# Altium schematic sheet Sheet1.SchDoc
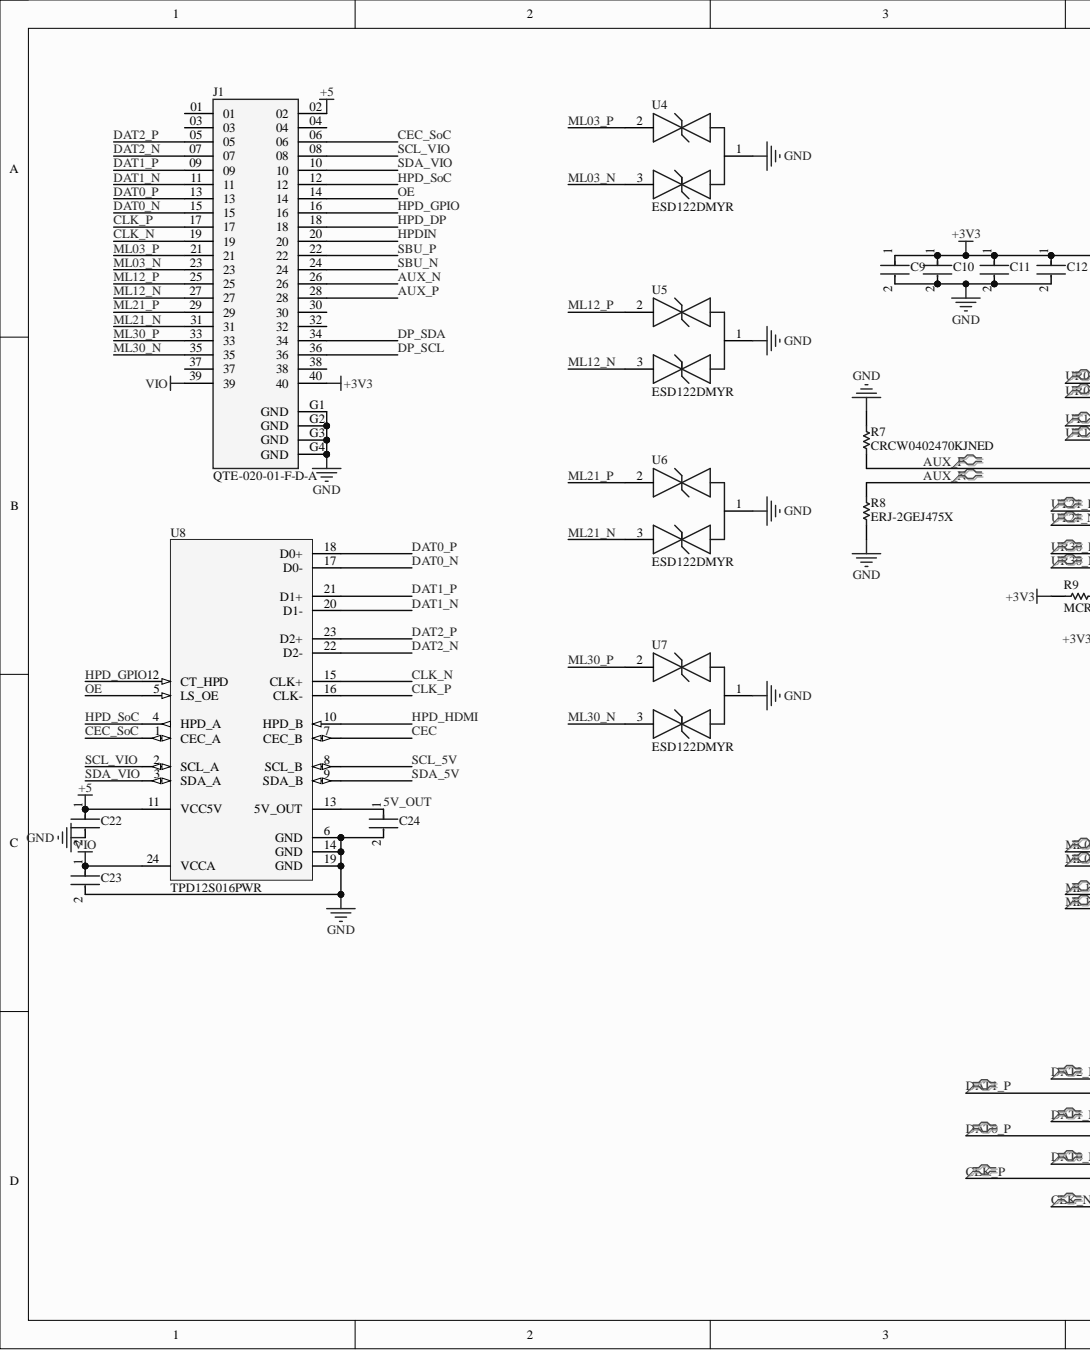
[diagram p0: Sheet1.SchDoc, left]
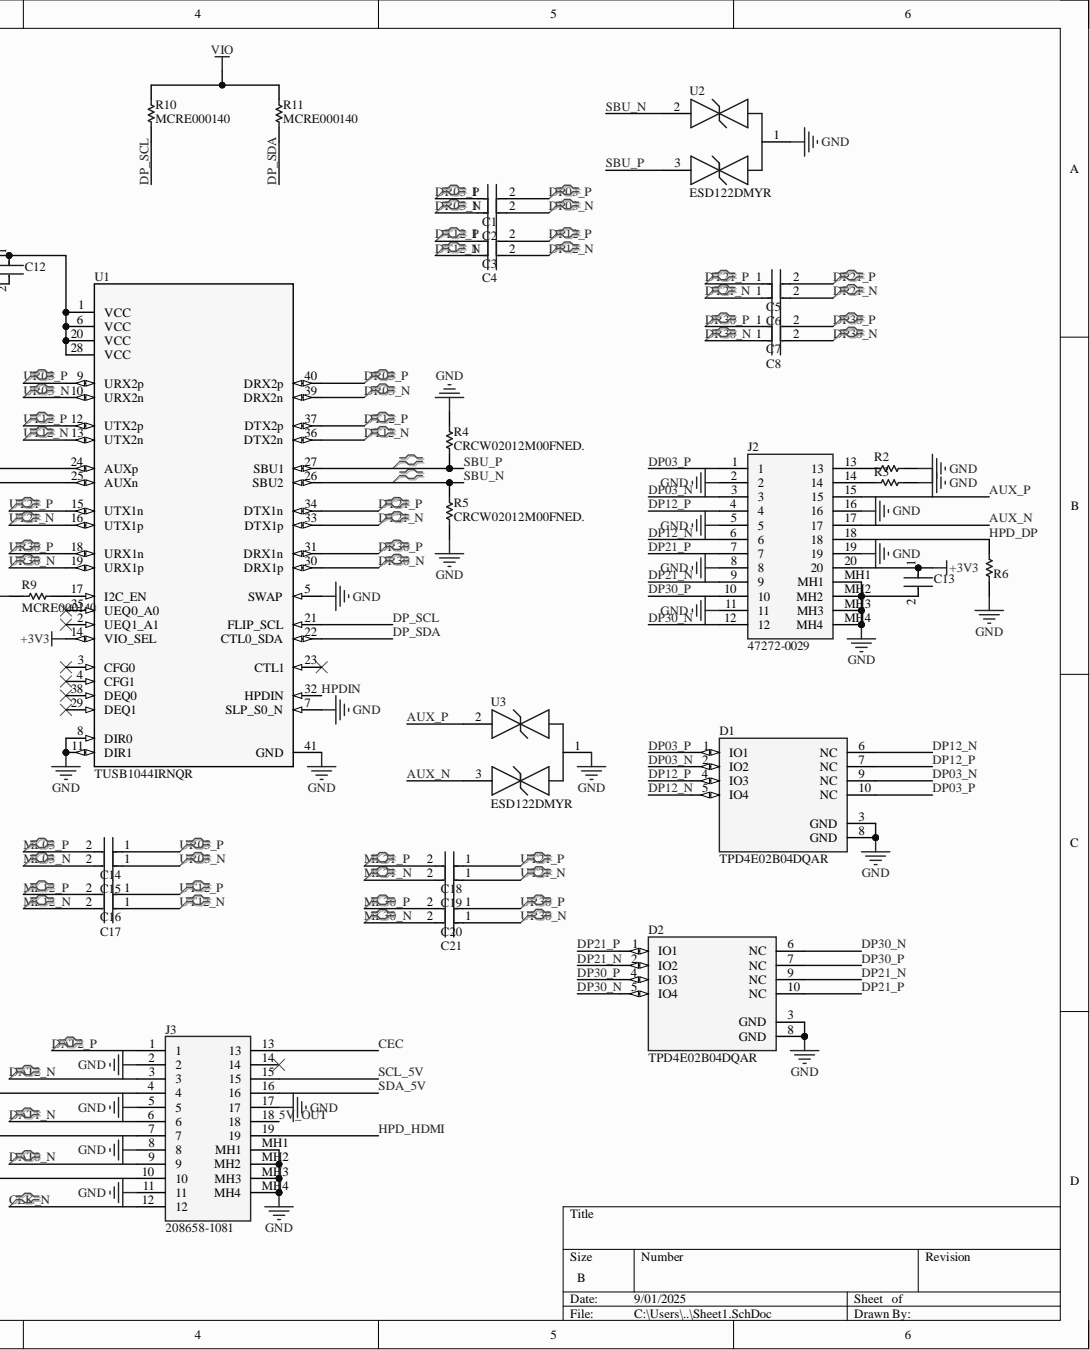
[diagram p1: Sheet1.SchDoc, right]

## Parts
C1  JMK105B7224MV-F  [FP-0402-L_1_0_0_05-W_0_5-MFG]  (value not drawn)
C2  JMK105B7224MV-F  [FP-0402-L_1_0_0_05-W_0_5-MFG]  (value not drawn)
C3  JMK105B7224MV-F  [FP-0402-L_1_0_0_05-W_0_5-MFG]  (value not drawn)
C4  JMK105B7224MV-F  [FP-0402-L_1_0_0_05-W_0_5-MFG]  (value not drawn)
C5  JMK105B7224MV-F  [FP-0402-L_1_0_0_05-W_0_5-MFG]  (value not drawn)
C6  JMK105B7224MV-F  [FP-0402-L_1_0_0_05-W_0_5-MFG]  (value not drawn)
C7  JMK105B7224MV-F  [FP-0402-L_1_0_0_05-W_0_5-MFG]  (value not drawn)
C8  JMK105B7224MV-F  [FP-0402-L_1_0_0_05-W_0_5-MFG]  (value not drawn)
C9  GRM033R60J104KE19J  [FP-GRM033-0_03-MFG]  (value not drawn)
C10  GRM033R60J104KE19J  [FP-GRM033-0_03-MFG]  (value not drawn)
C11  GRM033R60J104KE19J  [FP-GRM033-0_03-MFG]  (value not drawn)
C12  GRM033R60J104KE19J  [FP-GRM033-0_03-MFG]  (value not drawn)
C13  GRM033R60J104KE19J  [FP-GRM033-0_03-MFG]  (value not drawn)
C14  JMK105B7224MV-F  [FP-0402-L_1_0_0_05-W_0_5-MFG]  (value not drawn)
C15  JMK105B7224MV-F  [FP-0402-L_1_0_0_05-W_0_5-MFG]  (value not drawn)
C16  JMK105B7224MV-F  [FP-0402-L_1_0_0_05-W_0_5-MFG]  (value not drawn)
C17  JMK105B7224MV-F  [FP-0402-L_1_0_0_05-W_0_5-MFG]  (value not drawn)
C18  JMK105B7224MV-F  [FP-0402-L_1_0_0_05-W_0_5-MFG]  (value not drawn)
C19  JMK105B7224MV-F  [FP-0402-L_1_0_0_05-W_0_5-MFG]  (value not drawn)
C20  JMK105B7224MV-F  [FP-0402-L_1_0_0_05-W_0_5-MFG]  (value not drawn)
C21  JMK105B7224MV-F  [FP-0402-L_1_0_0_05-W_0_5-MFG]  (value not drawn)
C22  GRM033R60J104KE19J  [FP-GRM033-0_03-MFG]  (value not drawn)
C23  GRM033R60J104KE19J  [FP-GRM033-0_03-MFG]  (value not drawn)
C24  GRM033R60J104KE19J  [FP-GRM033-0_03-MFG]  (value not drawn)
D1  TPD4E02B04DQAR  [FP-DQA0010A-MFG]
D2  TPD4E02B04DQAR  [FP-DQA0010A-MFG]
J1  QTE-020-01-F-D-A  [SAMTEC_QTE-020-01-X-D-A]
J2  47272-0029  [472720029]
J3  208658-1081  [2086581081]
R2  1M 1% 0201(0603)  [RESC0201(0603)_L]  (value not drawn)
R3  1M 1% 0201(0603)  [RESC0201(0603)_L]  (value not drawn)
R4  CRCW02012M00FNED.  [RESC0603X28X15ML05T05]
R5  CRCW02012M00FNED.  [RESC0603X28X15ML05T05]
R6  1M 1% 0201(0603)  [RESC0201(0603)_L]  (value not drawn)
R7  CRCW0402470KJNED  [RESC1005X40X25NL05T05]
R8  ERJ-2GEJ475X  [RESC1005X40X25LL05T05]
R9  MCRE000140  [RESC0603X26X15ML03T05]
R10  MCRE000140  [RESC0603X26X15ML03T05]
R11  MCRE000140  [RESC0603X26X15ML03T05]
U1  TUSB1044IRNQR  [RNQ0040A]
U2  ESD122DMYR  [FP-DMY0003A-MFG]
U3  ESD122DMYR  [FP-DMY0003A-MFG]
U4  ESD122DMYR  [FP-DMY0003A-MFG]
U5  ESD122DMYR  [FP-DMY0003A-MFG]
U6  ESD122DMYR  [FP-DMY0003A-MFG]
U7  ESD122DMYR  [FP-DMY0003A-MFG]
U8  TPD12S016PWR  [PW0024A_N]

## Nets
+3V3: C9.1 C10.1 C11.1 C12.1 C13.1 J1.40 J2.20 R9.2 U1.1(VCC) U1.6(VCC) U1.14(VIO_SEL) U1.20(VCC) U1.28(VCC)
GND: C9.2 C10.2 C11.2 C12.2 C13.2 C22.2 C23.2 C24.2 D1.3(GND) D1.8(GND) D2.3(GND) D2.8(GND) J1.G1(GND) J1.G2(GND) J1.G3(GND) J1.G4(GND) J2.2 J2.5 J2.8 J2.11 J2.16 J2.19 J2.MH1 J2.MH2 J2.MH3 J2.MH4 J3.2 J3.5 J3.8 J3.11 J3.17 J3.MH1 J3.MH2 J3.MH3 J3.MH4 R2.2 R3.2 R4.2 R5.1 R6.1 R7.2 R8.1 U1.5(SWAP) U1.7(SLP_S0_N) U1.8(DIR0) U1.11(DIR1) U1.41(GND) U2.1 U3.1 U4.1 U5.1 U6.1 U7.1 U8.6(GND) U8.14(GND) U8.19(GND)
VIO: C23.1 J1.39 R10.2 R11.2 U8.24(VCCA)
5V_OUT: C22.1 C24.1 J1.02 J3.18 U8.11(VCC5V) U8.13(5V_OUT)
AUX_N: J1.26 J2.17 R8.2 U1.25(AUXn) U3.3
AUX_P: J1.28 J2.15 R7.1 U1.24(AUXp) U3.2
CEC: J3.13 U8.7(CEC_B)
CEC_SoC: J1.06 U8.1(CEC_A)
CLK_N: J1.19 J3.12 U8.15(CLK+)
CLK_P: J1.17 J3.10 U8.16(CLK-)
DAT0_N: J1.15 J3.9 U8.17(D0-)
DAT0_P: J1.13 J3.7 U8.18(D0+)
DAT1_N: J1.11 J3.6 U8.20(D1-)
DAT1_P: J1.09 J3.4 U8.21(D1+)
DAT2_N: J1.07 J3.3 U8.22(D2-)
DAT2_P: J1.05 J3.1 U8.23(D2+)
DP03_N: C2.2 D1.2(IO2) D1.9(NC) J2.3
DP03_P: C1.2 D1.1(IO1) D1.10(NC) J2.1
DP12_N: C4.2 D1.5(IO4) D1.6(NC) J2.6
DP12_P: C3.2 D1.4(IO3) D1.7(NC) J2.4
DP21_N: C6.2 D2.2(IO2) D2.9(NC) J2.9
DP21_P: C5.2 D2.1(IO1) D2.10(NC) J2.7
DP30_N: C8.2 D2.5(IO4) D2.6(NC) J2.12
DP30_P: C7.2 D2.4(IO3) D2.7(NC) J2.10
DP_SCL: J1.36 R10.1 U1.21(FLIP_SCL)
DP_SDA: J1.34 R11.1 U1.22(CTL0_SDA)
DR03_N: C2.1 U1.39(DRX2n)
DR03_P: C1.1 U1.40(DRX2p)
DR30_N: C8.1 U1.30(DRX1p)
DR30_P: C7.1 U1.31(DRX1n)
DT12_N: C4.1 U1.36(DTX2n)
DT12_P: C3.1 U1.37(DTX2p)
DT21_N: C6.1 U1.33(DTX1p)
DT21_P: C5.1 U1.34(DTX1n)
HPD_DP: J1.18 J2.18 R6.2
HPD_GPIO: J1.16 U8.12(CT_HPD)
HPD_HDMI: J3.19 U8.10(HPD_B)
HPD_SoC: J1.12 U8.4(HPD_A)
HPDIN: J1.20 U1.32(HPDIN)
ML03_N: C15.2 J1.23 U4.3
ML03_P: C14.2 J1.21 U4.2
ML12_N: C17.2 J1.27 U5.3
ML12_P: C16.2 J1.25 U5.2
ML21_N: C19.2 J1.31 U6.3
ML21_P: C18.2 J1.29 U6.2
ML30_N: C21.2 J1.35 U7.3
ML30_P: C20.2 J1.33 U7.2
OE: J1.14 U8.5(LS_OE)
SBU_N: J1.24 R5.2 U1.26(SBU2) U2.2
SBU_P: J1.22 R4.1 U1.27(SBU1) U2.3
SCL_5V: J3.15 U8.8(SCL_B)
SCL_VIO: J1.08 U8.2(SCL_A)
SDA_5V: J3.16 U8.9(SDA_B)
SDA_VIO: J1.10 U8.3(SDA_A)
UR03_N: C15.1 U1.10(URX2n)
UR03_P: C14.1 U1.9(URX2p)
UR30_N: C21.1 U1.19(URX1p)
UR30_P: C20.1 U1.18(URX1n)
UT12_N: C17.1 U1.13(UTX2n)
UT12_P: C16.1 U1.12(UTX2p)
UT21_N: C19.1 U1.16(UTX1p)
UT21_P: C18.1 U1.15(UTX1n)
NetJ2_13: J2.13 R2.1
NetJ2_14: J2.14 R3.1
NetR9_1: R9.1 U1.17(I2C_EN)

Not connected: J1 01 03 04 30 32 37 38; J3 14; U1 2(UEQ1_A1) 3(CFG0) 4(CFG1) 23(CTL1) 29(DEQ1) 35(UEQ0_A0) 38(DEQ0)

## Library data of the parts
C1-C8 C14-C21 = CMP-14477-001612-2: None; Capacitance: 220nF; Dielectric: X7R; Tolerance: 20%; Voltage: 6.3V; Case/Package: Ceramic; Component Kind: Standard; Depth: 0.5mm; Halogen Free: Halogen Free; Height: 0.5mm; Lead Free: Lead Free; Length: 1mm; Library Name: Altium Content Vault; Max Operating Temperature: 125°C; Min Operating Temperature: -55°C; Mount: Surface Mount; Packaging: Tape and Reel; Radiation Hardening: No; RoHS Compliant: Yes; Voltage Rating (DC): 6.3V
C9-C13 C22-C24 = CMP-2010-01258-2: Chip Multilayer Ceramic Capacitors for General Purpose, 0201, 0.10uF, X5R, 15%, 10%, 6.3V; Capacitance: 100nF; Case Code (Imperial): 0201; Case Code (Metric): 0603; Case/Package: 0201; Component Kind: Standard; Depth: 0.3mm; Dielectric: X5R; Height: 0.3mm; Lead Free: Lead Free; Length: 0.6mm; Library Name: Altium Content Vault; Max Operating Temperature: 85°C; Min Operating Temperature: -55°C; Mount: Surface Mount; Package Quantity: 50000; Packaging: Tape and Reel; Radiation Hardening: No; Termination: SMD/SMT; Thickness: 0.013inch; Tolerance: 10%; Voltage Rating: 6.3V; Voltage Rating (DC): 6.3V; Width: 0.012inch
D1 D2 = CMP-04989-000015-1: TVS DIODE 3.6V 8.8V 10USON; Interface: USB; Min Breakdown Voltage: 5.5V; Number of Bidirectional Channels: 4; Peak Pulse Current: 5A; Peak Pulse Power: 17W; Power Line Protection: No; Clamping Voltage: 8.8V; Component Kind: Standard; Lead Free: Lead Free; Library Name: Altium Content Vault; Max Operating Temperature: 125°C; Min Operating Temperature: -40°C; Mount: Surface Mount; Number of Channels: 4; Number of Pins: 10; Operating Supply Voltage: 3.6V; Packaging: Cut Tape; REACH SVHC: No SVHC; Resistor Type: Zener; Reverse Standoff Voltage: 3.6V; RoHS Compliant: Yes
J1 = QTE-020-01-X-D-A: MF: Samtec; Availability: Unavailable; Component Kind: Standard; Library Name: QTE-020-01-X-D-A.SchLib; MP: QTE-020-01-X-D-A; Package: None; Price: None
J2 = 47272-0029: Connector; Manufacturer_Name: Molex; Component Kind: Standard; Datasheet Link: https://www.molex.com/pdm_docs/sd/472720029_sd.pdf; Height: 6.9mm; Manufacturer_Part_Number: 47272-0029; Mouser Part Number: 538-47272-0029; Mouser Price/Stock: https://www.mouser.co.uk/ProductDetail/Molex/47272-0029?qs=R8Vl3J6srxyrbHtT7SkUeQ%3D%3D
J3 = 208658-1081: Connector; Manufacturer_Name: Molex; Component Kind: Standard; Datasheet Link: https://www.molex.com/pdm_docs/sd/2086581081_sd.pdf; Height: 6.44mm; Manufacturer_Part_Number: 208658-1081; Mouser Part Number: 538-208658-1081; Mouser Price/Stock: https://www.mouser.co.uk/ProductDetail/Molex/208658-1081?qs=wnTfsH77Xs42S8XwiJrhYg%3D%3D
R2 R3 R6 = CMP-1010-00755-1: 1M 0.05W 1% 0201 (0603 Metric)  SMD; Case-EIA: 0201; Case-Metric: 0603; Value: 1000000.00 Ohm; Component Kind: Standard; Library Name: Altium Content Vault; Power: 0.05 W; Technology: SMT; Tolerance: 1 %
R4 R5 = CMP-2004-00439-1: Manufacturer: Vishay Dale; Component Kind: Standard; Library Name: Altium Content Vault; Part Number: CRCW02012M00FNED.
R7 = CMP-2002-00021-1: Manufacturer: Vishay Dale; Component Kind: Standard; Library Name: Altium Content Vault; Part Number: CRCW0402470KJNED
R8 = CMP-2002-00547-1: Manufacturer: Panasonic; Component Kind: Standard; Library Name: Altium Content Vault; Part Number: ERJ-2GEJ475X
R9-R11 = CMP-2004-02508-1: Manufacturer: Multicomp; Component Kind: Standard; Library Name: Altium Content Vault; Part Number: MCRE000140
U1 = TUSB1044IRNQR: No Description Available; Mfr_Name: Texas Instruments; Component Kind: Standard; Library Name: TUSB1044IRNQR.SchLib; RefDes: RefDes; TYPE: TYPE
U2-U7 = CMP-04985-000020-1: TVS DIODE 3.6V 8.4V 3X2SON; Interface: USB; Min Breakdown Voltage: 5.1V; Number of Bidirectional Channels: 2; Peak Pulse Current: 2.5A; Peak Pulse Power: 20W; Power Line Protection: No; Clamping Voltage: 8.4V; Component Kind: Standard; Library Name: Altium Content Vault; Max Operating Temperature: 125°C; Min Operating Temperature: -40°C; Mount: Surface Mount; Number of Channels: 2; Number of Pins: 3; Packaging: Tape and Reel; Resistor Type: Zener; Reverse Standoff Voltage: 3.6V; RoHS Compliant: Yes
U8 = CMP-0326-00070-3: Value-Line HDMI Companion Chip for Portable Applications, 12 Channels, -40 to +85 degC, 24-pin TSSOP (PW), Green (RoHS & no Sb/Br); Manufacturer: Texas Instruments; Breakdown Voltage(Min)(V): 9; Differential Capacitance(pF): 0.05; IEC 61000-4-2 Contact(+/- kV): 8; IO Capacitance(Typ)(pF): 1; IO Leakage Current(nA): 1000; SubFamily: ESD Solution; Component Kind: Standard; ComponentLink1Description: Manufacturer URL; ComponentLink1URL: http://www.ti.com/; ComponentLink2Description: Datasheet; ComponentLink2URL: http://www.ti.com/general/docs/lit/getliterature.tsp?genericPartNumber=TPD12S016&fileType=pdf; ComponentLink3Description: URL Link1; ComponentLink3URL: SamplesURL:http://www.ti.com/product/tpd12s016#samples; DatasheetVersion: SLLSE96A; Eco-Plan: Green (RoHS & no Sb/Br); Library Name: Altium Content Vault; Mounting Technology: Surface Mount; PackageDescription: 24-Pin Thin Small Outline Package 0.65 mm Pitch; PackageReference: PW0024A; PackageVersion: revG, Feb-2011; PartNumber: TPD12S016PWR
